# Altium schematic sheet Measurment ICs.SchDoc("Measurment ICs")
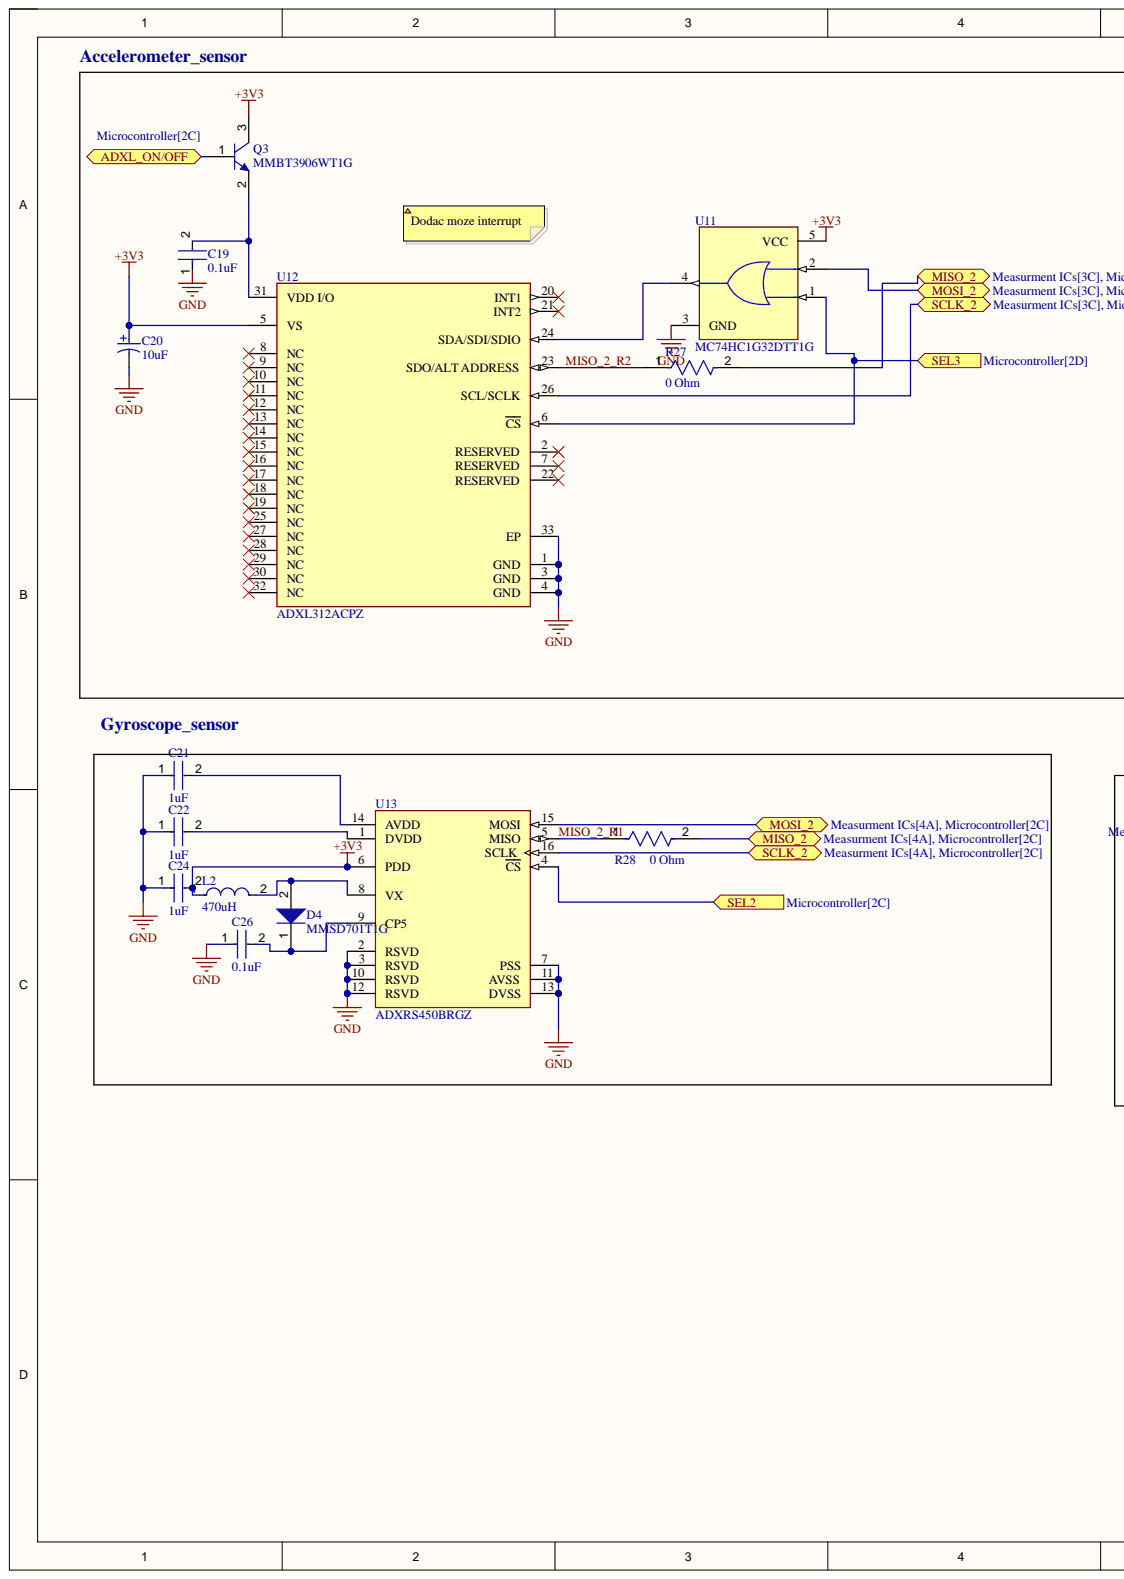
[diagram p0: Measurment ICs.SchDoc, left]
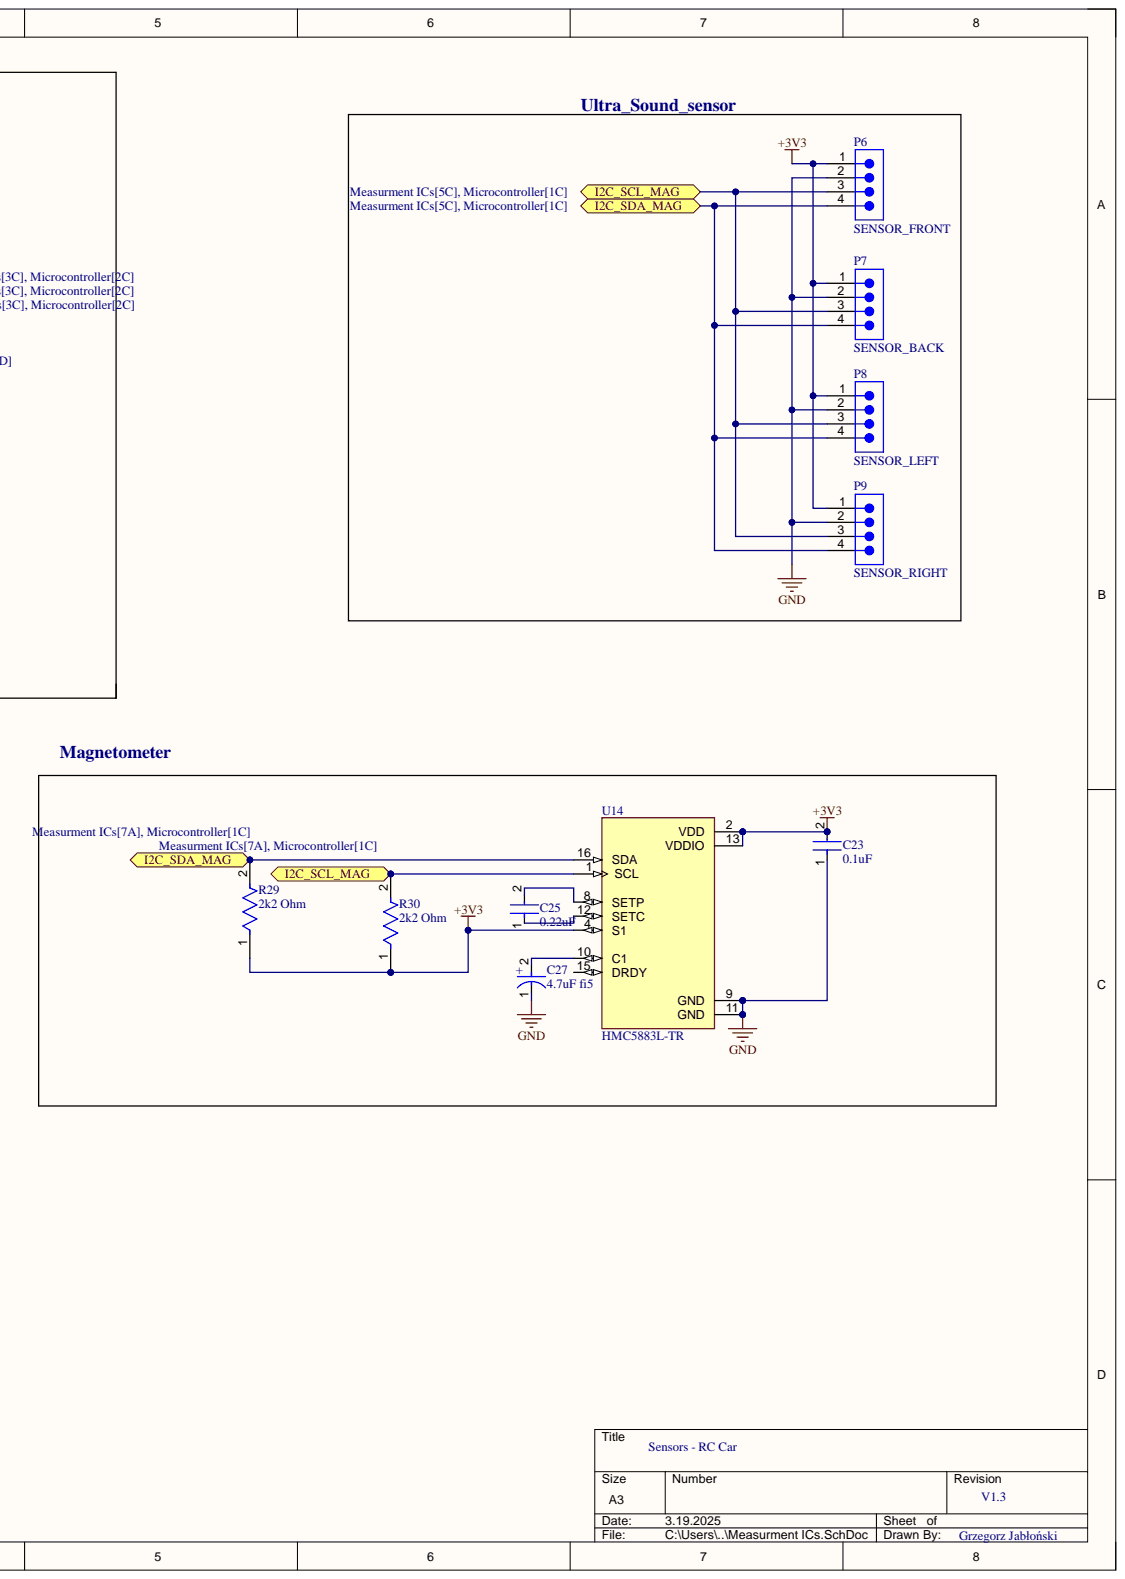
[diagram p1: Measurment ICs.SchDoc, right]

## Parts
C19  0.1uF  [FP-CC0805-0_85-MFG]
C20  10uF  [KEMT-A-3216-18-2_M]
C21  1uF  [FP-CC0805-0_85-MFG]
C22  1uF  [FP-CC0805-0_85-MFG]
C23  0.1uF  [FP-CC0805-0_85-MFG]
C24  1uF  [FP-CC0805-0_85-MFG]
C25  0.22uF  [FP-CC0805-0_85-MFG]
C26  0.1uF  [FP-CC0805-0_85-MFG]
C27  4.7uF fi5  [FP-RAD-TH-D_5_0_5-L_11_1-MFG]
D4  MMSD701T1G  [ONSC-SOD-123-2-425-04_V]
L2  470uH  [FP-LQH43CN_03-MFG]
P6  SENSOR_FRONT  [61300411121]
P7  SENSOR_BACK  [61300411121]
P8  SENSOR_LEFT  [61300411121]
P9  SENSOR_RIGHT  [61300411121]
Q3  MMBT3906WT1G  [ONSC-SC-70-3-419-04_V]
R27  0 Ohm  [FP-RMCF0805-MFG]
R28  0 Ohm  [FP-RMCF0805-MFG]
R29  2k2 Ohm  [FP-RMCF0805-MFG]
R30  2k2 Ohm  [FP-RMCF0805-MFG]
U11  MC74HC1G32DTT1G  [FP-483-MFG]
U12  ADXL312ACPZ  [FP-CP-32-17-MFG]
U13  ADXRS450BRGZ  [FP-RG-16-1-IPC_C]
U14  HMC5883L-TR  [XDCR_HMC5883L-TR]

## Ports
ADXL_ON/OFF, I2C_SCL_MAG, I2C_SDA_MAG, MISO_2, MOSI_2, SCLK_2, SEL2, SEL3

## Nets
+3V3: C20.1 C23.2 C24.2 L2.1 P6.1 P7.1 P8.1 P9.1 Q3.3 R29.1 R30.1 U11.5(VCC) U12.5(VS) U13.6(PDD) U14.2(VDD) U14.4(S1) U14.13(VDDIO)
GND: C19.1 C20.2 C21.1 C22.1 C23.1 C24.1 C26.1 C27.1 P6.2 P7.2 P8.2 P9.2 U11.3(GND) U12.1(GND) U12.3(GND) U12.4(GND) U12.33(EP) U13.2(RSVD) U13.3(RSVD) U13.7(PSS) U13.10(RSVD) U13.11(AVSS) U13.12(RSVD) U13.13(DVSS) U14.9(GND) U14.11(GND)
ADXL_ON/OFF: Q3.1 ; port ADXL_ON/OFF
I2C_SCL_MAG: P6.3 P7.3 P8.3 P9.3 R30.2 U14.1(SCL) ; port I2C_SCL_MAG
I2C_SDA_MAG: P6.4 P7.4 P8.4 P9.4 R29.2 U14.16(SDA) ; port I2C_SDA_MAG
MISO_2: R27.2 R28.2 ; port MISO_2
MISO_2_R1: R28.1 U13.5(MISO)
MISO_2_R2: R27.1 U12.23(SDO/ALT_ADDRESS)
MOSI_2: U11.2 U13.15(MOSI) ; port MOSI_2
SCLK_2: U12.26(SCL/SCLK) U13.16(SCLK) ; port SCLK_2
SEL2: U13.4(CS) ; port SEL2
SEL3: U11.1 U12.6(CS) ; port SEL3
NetC19_2: C19.2 Q3.2 U12.31(VDD_I/O)
NetC21_2: C21.2 U13.14(AVDD)
NetC22_2: C22.2 U13.1(DVDD)
NetC25_1: C25.1 U14.12(SETC)
NetC25_2: C25.2 U14.8(SETP)
NetC26_2: C26.2 D4.1 U13.9(CP5)
NetC27_2: C27.2 U14.10(C1)
NetD4_2: D4.2 L2.2 U13.8(VX)
NetU11_4: U11.4 U12.24(SDA/SDI/SDIO)

Not connected: U12 2(RESERVED) 7(RESERVED) 8(NC) 9(NC) 10(NC) 11(NC) 12(NC) 13(NC) 14(NC) 15(NC) 16(NC) 17(NC) 18(NC) 19(NC) 20(INT1) 21(INT2) 22(RESERVED) 25(NC) 27(NC) 28(NC) 29(NC) 30(NC) 32(NC); U14 15(DRDY)

## Library data of the parts
C19 C21-C26 = CMP-2100-03606-3: CAP CER 0.1UF 50V X7R 0805; Manufacturer: Yageo; Manufacturer Part Number: CC0805KRX7R9BB104; Capacitance: 100 nF; Material: Ceramic; Tolerance: 0.1; Value: 100 nF; Automotive: No; Category: Cap; Component Kind: Standard; ComponentLink2Description: Datasheet; ComponentLink2URL: http://www.yageo.com/documents/recent/UPY-GPHC_Y5V_6.3V-to-50V_8.pdf; Device Class L1: Passive Components; Device Class L2: Capacitors; Device Class L3: Ceramic Capacitors; Digikey Description: CAP CER 0.1UF 50V X7R 0805; Footprint Url: http://www.yageo.com/exep/pages/download/literatures/UPY-C_GEN_24.pdf; Height: 0.95mm; Ipc Land Pattern Name: CAPC200125X85; Lead Free: Yes; Library Name: Altium Content Vault; Mouser Description: Multilayer Ceramic Capacitors MLCC - SMD/SMT 100nF 50V X7R 10%; Octopart: https://octopart.com/cc0805krx7r9bb104-yageo-192993; Package: CC0805-0.85; RoHS: TRUE; Temperature: -30°C to +85°C; Temperature Characteristic: X7R; Temperature Range High: +85°C; Temperature Range Low: -30°C; Voltage Rating: 50 V
C20 = CMP-2000-05172-1: Capacitor, Tantalum, 10 uF, +/- 10%, 10 V, -55 to 125 degC, 2-Pin SMD (1206), RoHS, Tape and Reel; Manufacturer: Kemet; Manufacturer Size Code: A; Capacitance: 10µF; Catalog Drawings: T49x,T5xxSeries_A-side1T49x,T5xxSeries_A,B-side2T49x,T5xxSeries_A,SBottom; ESR (Equivalent Series Resistance): 3.8Ohm; Lifetime @ Temp.: 2000Hrs@85degC; Online Catalog: T491Series; Other Names: 399-3684-2; Product Training Modules: CapacitorBasics-TypicalUsesforCapacitorsDeratingGuidelinesforSurfaceMountTantalumCapacitors; Type: Molded; Voltage - Rated: 10V; Category: Capacitors; Component Kind: Standard; Datasheet URL: http://www.farnell.com/datasheets/1824869.pdf; Datasheet Version: Apr-14; Family: TantalumCapacitors; Features: GeneralPurpose; Height - Seated (Max): 0.071"(1.80mm); Lead Spacing: -; Library Name: Altium Content Vault; Manufacturer URL: http://www.kemet.com/; Mounting Type: SurfaceMount; Operating Temperature: -55degC~125degC; Package / Case: 1206(3216Metric); Package Description: 2-Pin Surface Mount Device, Body 3.2 x 1.6 mm; Package Reference: 1206; Packaging: Tape&Reel(TR); Series: T491; Size / Dimension: 0.126"Lx0.063"W(3.20mmx1.60mm); Standard Package: 2,000; Tolerance: ±10%
C27 = CMP-05427-001989-1: Aluminum Electrolytic Capacitors (Radial Lead Type) AEC-Q200 Qualified 22uF ±20% 50V; ESR (Equivalent Series Resistance): 1.3R; Lead Diameter: 0.5mm; Leakage Current: 3uA; Life (Hours): 1000Hour; Polarity: Polar; Ripple Current: 155mA; Capacitance: 22uF; Case/Package: Radial; Component Kind: Standard; Contact Plating: Tin; Diameter: 5mm; Features: General Purpose; Height: 11mm; Height - Seated (Max): 0.472inch; Impedance: 1.3R; Lead Pitch: 0.197inch; Length: 11mm; Library Name: Altium Content Vault; Max Operating Temperature: 105°C; Min Operating Temperature: -55°C; Mount: Through Hole; Package Quantity: 2000; Packaging: Tape and Reel; Radiation Hardening: No; RoHS Compliant: Yes; Series: FC; Termination: Radial; Tolerance: 20%; Voltage Rating: 50V; Voltage Rating (DC): 50V
D4 = CMP-1055-00417-1: Schottky Barrier Diode, 2-Pin SOD-123, Pb-Free, Tape and Reel; Manufacturer: On Semiconductor; Configuration: Single; Component Kind: Standard; ComponentLink1Description: Manufacturer URL; ComponentLink1URL: http://www.onsemi.com/; ComponentLink2Description: Datasheet; ComponentLink2URL: http://www.onsemi.cn/pub_link/Collateral/MMSD301T1-D.PDF; ComponentLink3Description: Package Specification; ComponentLink3URL: http://www.onsemi.com/pub_link/Collateral/425-04.PDF; DatasheetVersion: Rev. 6; Library Name: Altium Content Vault; Mounting Technology: Surface Mount; PackageDescription: 2-Pin SOD, Body 1.6 x 2.69 mm; PackageReference: SOD-123-2-425-04; PackageVersion: Rev. G, 10/2009; Packing: Tape and Reel; PartNumber: MMSD701T1G; RoHS: TRUE
L2 = CMP-06042-007054-1: Wire Wound Ferrite Inductor for General Circuits 470μH ±10% 11.8Ω 80mA 1812; DC Resistance (DCR): 11.8R; Frequency: 3MHz; Q Factor: 40; Shielding: Unshielded; Test Frequency: 1kHz; Case Code (Imperial): 1812; Case Code (Metric): 4532; Case/Package: 1812; Component Kind: Standard; Core Material: Ferrite; Current Rating: 80mA; DC Current: 80mA; Depth: 3.4mm; Height: 2.6mm; Height - Seated (Max): 0.11inch; Inductance: 470uH; Lead Free: Lead Free; Length: 4.5mm; Library Name: Altium Content Vault; Max DC Current: 80mA; Max Operating Temperature: 85°C; Military Standard: Not; Min Operating Temperature: -40°C; Mount: Surface Mount; Package Quantity: 500; Packaging: Tape and Reel; Radiation Hardening: No; Resistor Type: Wirewound; Self Resonant Frequency: 3MHz; Series Resistance: 11.8R; Termination: SMD/SMT; Tolerance: 10%; Weight: 0.004586oz; Width: 3.2mm
P6-P9 = CMP-1502-01064-3: NexarPartId: 32855522; Connector Type: Male Pin; Contact Resistance: 20mΩ; Current Rating: 3A; Gender: Male; Number of Contacts: 4; Operating Supply Voltage: 250V; Pitch: 2.54mm; Color: Black; Component Kind: Standard; Contact Material: Copper; Contact Mating Length: 5.9944mm; Contact Plating: Gold; Height: 8.89mm; Lead Free: Lead Free; Lead Pitch: 2.54mm; Library Name: Altium Content Vault; Max Operating Temperature: 105°C; Min Operating Temperature: -40°C; Mount: Through Hole; Number of Pins: 4; Number of Positions: 4; Number of Rows: 1; Orientation: Vertical; Packaging: Bulk; REACH SVHC: Unknown; RoHS: Compliant; Termination: Solder; Voltage Rating: 250V
Q3 = CMP-1048-00381-1: General Purpose Transistor, PNP Silicon, 3-Pin SC70, Pb-Free, Tape and Reel; Manufacturer: On Semiconductor; fT Min (MHz): 250; IC Continuous (A): -0.2; Component Kind: Standard; ComponentLink1Description: Manufacturer URL; ComponentLink1URL: http://www.onsemi.com/; ComponentLink2Description: Datasheet; ComponentLink2URL: http://www.onsemi.cn/pub_link/Collateral/MMBT3904WT1-D.PDF; ComponentLink3Description: Package Specification; ComponentLink3URL: http://www.onsemi.cn/pub_link/Collateral/419-04.PDF; DatasheetVersion: Rev. 8; Library Name: Altium Content Vault; Mounting Technology: Surface Mount; PackageDescription: 3-Pin Small Outline Transistor, Body 2 x 1.25 mm, Pitch 0.65 mm; PackageReference: SC-70-3-419-04; PackageVersion: Rev. N, 11/2008; Packing: Tape and Reel; PartNumber: MMBT3906WT1G; Polarity: PNP; RoHS: TRUE
R27-R30 = CMP-26527-000017-1: RES 0 OHM JUMPER 1/8W 0805; Max Power Dissipation: 125mW; Resistance: 0mR; Case Code (Imperial): 0805; Case Code (Metric): 2012; Case/Package: 0805; Component Kind: Standard; Composition: Thick Film; Contact Plating: Tin; Depth: 1.25mm; Features: Automotive AEC-Q200; Height: 0.026inch; Lead Free: Lead Free; Length: 2mm; Library Name: Altium Content Vault; Max Operating Temperature: 155°C; Military Standard: Not; Min Operating Temperature: -55°C; Mount: Surface Mount; Number of Terminations: 2; Packaging: Tape and Reel; Power Rating: 125mW; Radiation Hardening: No; Width: 0.049inch
U11 = CMP-00278-00467123-1: MC74HC1G32DTT1G MC74HC Series 4 V Surface Mount Single 2-Input OR Gate - TSOP-5; Manufacturer Lifecycle Status: ACTIVE; NexarPartId: 467123; High Level Output Current: -2.6mA; Low Level Output Current: 2.6mA; Max Junction Temperature (Tj): 150°C; Max Supply Voltage: 6V; Min Supply Voltage: 2V; Number of Channels: 1; Number of Inputs: 2; Operating Supply Voltage: 4V; Propagation Delay: 7ns; Quiescent Current: 1µA; Schmitt Trigger Input: No; Turn-On Delay Time: 100ns; Ambient Temperature Range High: 125°C; Case/Package: TSOP; Component Kind: Standard; Contact Plating: Tin; Halogen Free: Halogen Free; Height: 1mm; Lead Free: Lead Free; Length: 3mm; Library Name: Altium Content Vault; Lifecycle Status: Production; Logic Function: OR; Max Operating Temperature: 125°C; Min Operating Temperature: -55°C; Mount: Surface Mount; Number of Circuits: 1; Number of Elements: 1; Number of Gates: 1; Number of Outputs: 1; Number of Pins: 5; Output Current: 2.6mA; Packaging: Tape & Reel (TR); Radiation Hardening: No; REACH SVHC: No SVHC; RoHS: Compliant; Schedule B: 8542390000, 8542390000; Width: 1.5mm
U12 = CMP-0885-00061-2: ACCEL 1.5-12G I2C/SPI 32LFCSP; -3db Bandwidth: 1.6kHz; Axis: X, Y, Z; Bandwidth: 3.125Hz; Interface: I2C; Max Supply Voltage: 3.6V; Min Supply Voltage: 2V; Nominal Supply Current: 170uA; Output Type: Digital; Sensitivity: 345LSB/g; Case/Package: LFCSP EP; Component Kind: Standard; Contact Plating: Tin; Depth: 5mm; Height: 1.5mm; Lead Free: Contains Lead; Length: 5mm; Library Name: Altium Content Vault; Max Operating Temperature: 105°C; Min Operating Temperature: -40°C; Number of Pins: 32; Operating Supply Current: 170uA; Package Quantity: 60; Packaging: Rail/Tube; Radiation Hardening: No; REACH SVHC: No SVHC; RoHS Compliant: Yes; Series: -; Supply Current: 170uA; Width: 5.1mm
U13 = CMP-09161-000004-1: SENS GYRO 300DEG/S DGTL 16SOIC; -3db Bandwidth: 80Hz; Axis: Z; Interface: SPI; Linearity: 99.75%; Max Supply Voltage: 5.25V; Min Supply Voltage: 3.15V; Nominal Supply Current: 6mA; Resolution: 16b; Bandwidth: 80Hz; Case/Package: SOIC; Component Kind: Standard; Height: 3.73mm; Lead Free: Contains Lead; Length: 10.3mm; Library Name: Altium Content Vault; Max Operating Temperature: 105°C; Min Operating Temperature: -40°C; Mount: Surface Mount; Number of Pins: 16; Operating Supply Current: 6mA; Output Type: SPI; Package Quantity: 1; Packaging: Rail/Tube; REACH SVHC: No SVHC; RoHS Compliant: Yes; Series: -; Supply Current: 6mA; Width: 7.8mm
U14 = HMC5883L-TR: Board Mount Hall Effect / Magnetic Sensors Sensors, Transducers Magnetic - Hall Effect, Digital Switch, Linear, Compass (ICs) - IC COMPASS 3 AXIS I2C 16LCC SMD; MF: STMicroelectronics; MP: HMC5883LTR; Availability: Not in stock; Check_prices: https://www.snapeda.com/parts/HMC5883LTR/STMicroelectronics/view-part/?ref=eda; Component Kind: Standard; Library Name: HMC5883L-TR.IntLib; Package: LCC-16 STMicroelectronics; Price: None; SnapEDA_Link: https://www.snapeda.com/parts/HMC5883LTR/STMicroelectronics/view-part/?ref=snap
